# Altium schematic sheet MCU.SchDoc
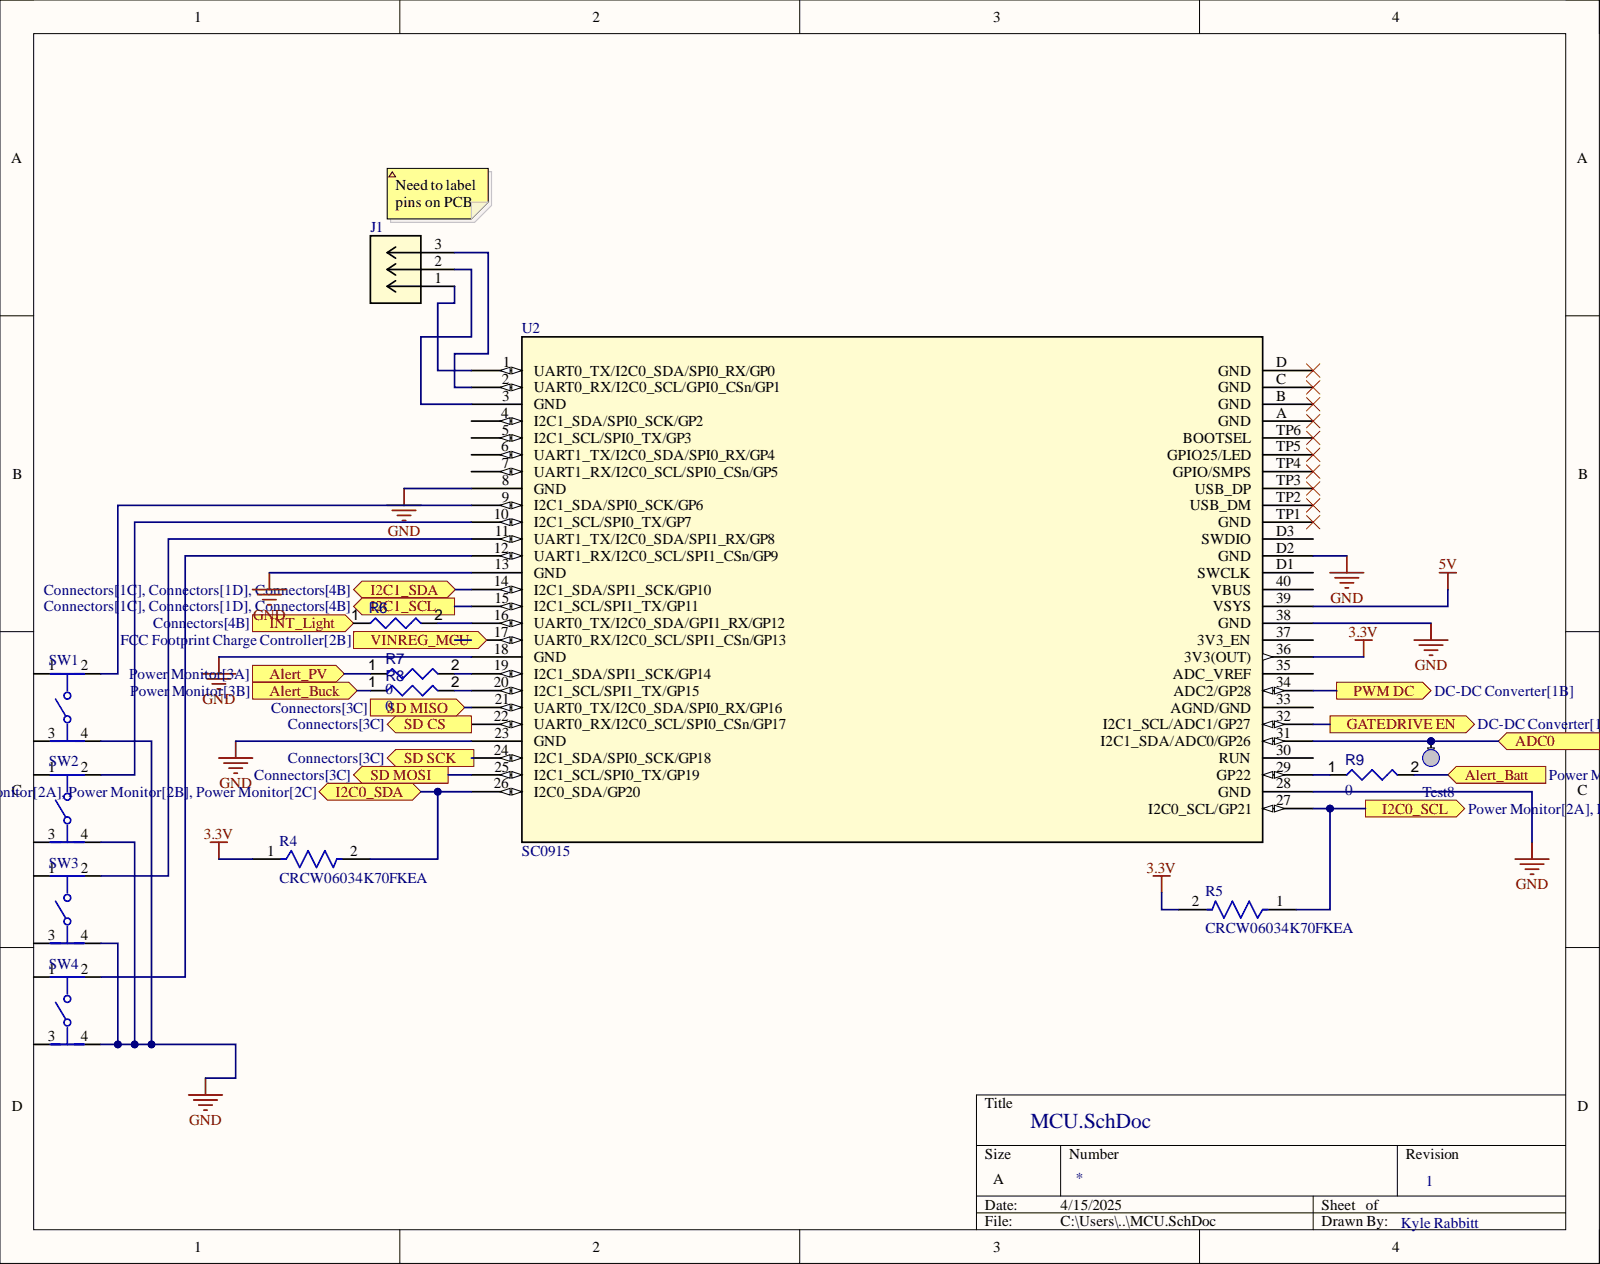
[diagram p0: MCU.SchDoc]

## Parts
J1  (no value)  [CONN_BM03B-SRSS-TBLFSN_JST]
R4  CRCW06034K70FKEA  [FP-CRCW0603-e3-IPC_A]
R5  CRCW06034K70FKEA  [FP-CRCW0603-e3-IPC_A]
R6  value 0  [RESC0603(1608)_L]  (hidden comment: 0R3J)
R7  0R3J  [RESC0603(1608)_L]  (value not drawn)
R8  value 0  [RESC0603(1608)_L]  (hidden comment: 0R3J)
R9  value 0  [RESC0603(1608)_L]  (hidden comment: 0R3J)
SW1  (no value)  [FP-PTS645SM43SMTR92LFS-MFG]
SW2  (no value)  [FP-PTS645SM43SMTR92LFS-MFG]
SW3  (no value)  [FP-PTS645SM43SMTR92LFS-MFG]
SW4  (no value)  [FP-PTS645SM43SMTR92LFS-MFG]
Test8  TestPoint  [Test_IN]  (value not drawn)  (no BOM)
U2  SC0915  [SC0915_RPI]

## Ports
ADC0, Alert_Batt, Alert_Buck, Alert_PV, GATEDRIVE EN, I2C0_SCL, I2C0_SDA, I2C1_SCL, I2C1_SDA, INT_Light, PWM DC, SD CS, SD MISO, SD MOSI, SD SCK, VINREG_MCU

## Nets
3.3V: R4.1 R5.2 U2.36(3V3(OUT))
5V: U2.39(VSYS)
GND: SW1.4 SW2.4 SW3.4 SW4.4 U2.8(GND) U2.13(GND) U2.18(GND) U2.23(GND) U2.28(GND) U2.38(GND) U2.D2(GND)
ADC0: Test8.IN U2.31(I2C1_SDA/ADC0/GP26) ; port ADC0
Alert_Batt: R9.2 ; port Alert_Batt
Alert_Buck: R8.1 ; port Alert_Buck
Alert_PV: R7.1 ; port Alert_PV
GATEDRIVE EN: U2.32(I2C1_SCL/ADC1/GP27) ; port GATEDRIVE EN
I2C0_SCL: R5.1 U2.27(I2C0_SCL/GP21) ; port I2C0_SCL
I2C0_SDA: R4.2 U2.26(I2C0_SDA/GP20) ; port I2C0_SDA
I2C1_SCL: U2.15(I2C1_SCL/SPI1_TX/GP11) ; port I2C1_SCL
I2C1_SDA: U2.14(I2C1_SDA/SPI1_SCK/GP10) ; port I2C1_SDA
INT_Light: R6.1 ; port INT_Light
PWM DC: U2.34(ADC2/GP28) ; port PWM DC
SD CS: U2.22(UART0_RX/I2C0_SCL/SPI0_CSn/GP17) ; port SD CS
SD MISO: U2.21(UART0_TX/I2C0_SDA/SPI0_RX/GP16) ; port SD MISO
SD MOSI: U2.25(I2C1_SCL/SPI0_TX/GP19) ; port SD MOSI
SD SCK: U2.24(I2C1_SDA/SPI0_SCK/GP18) ; port SD SCK
NetJ1_1: J1.1 U2.1(UART0_TX/I2C0_SDA/SPI0_RX/GP0)
NetJ1_2: J1.2 U2.3(GND)
NetJ1_3: J1.3 U2.2(UART0_RX/I2C0_SCL/GPI0_CSn/GP1)
NetR6_2: R6.2 U2.16(UART0_TX/I2C0_SDA/GPI1_RX/GP12)
NetR7_2: R7.2 U2.19(I2C1_SDA/SPI1_SCK/GP14)
NetR8_2: R8.2 U2.20(I2C1_SCL/SPI1_TX/GP15)
NetR9_1: R9.1 U2.29(GP22)
NetSW1_2: SW1.2 U2.9(I2C1_SDA/SPI0_SCK/GP6)
NetSW2_2: SW2.2 U2.10(I2C1_SCL/SPI0_TX/GP7)
NetSW3_2: SW3.2 U2.11(UART1_TX/I2C0_SDA/SPI1_RX/GP8)
NetSW4_2: SW4.2 U2.12(UART1_RX/I2C0_SCL/SPI1_CSn/GP9)
N00001: ; port VINREG_MCU

Not connected: SW1 1 3; SW2 1 3; SW3 1 3; SW4 1 3; U2 4(I2C1_SDA/SPI0_SCK/GP2) 5(I2C1_SCL/SPI0_TX/GP3) 6(UART1_TX/I2C0_SDA/SPI0_RX/GP4) 7(UART1_RX/I2C0_SCL/SPI0_CSn/GP5) 17(UART0_RX/I2C0_SCL/SPI1_CSn/GP13) 30(RUN) 33(AGND/GND) 35(ADC_VREF) 37(3V3_EN) 40(VBUS) A(GND) B(GND) C(GND) D(GND) D1(SWCLK) D3(SWDIO) TP1(GND) TP2(USB_DM) TP3(USB_DP) TP4(GPIO/SMPS) TP5(GPIO25/LED) TP6(BOOTSEL)

## Library data of the parts
J1 = BM03B-SRSS-TBLFSN: No Description Available; Mfr_Name: JST; Component Kind: Standard; Library Name: BM03B-SRSS-TBLFSN.SchLib; RefDes: RefDes; Type: Type
R4 R5 = CMP-1666-00019-3: RES Thick Film, 4.7kΩ, 1%, 0.1W, 100ppm/°C, 0603; Power Rating: 100mW; Resistance: 4.7kR; Voltage Rating: 75V; Case Code (Imperial): 0603; Case Code (Metric): 1608; Case/Package: 0603; Component Kind: Standard; Composition: Thick Film; Contact Plating: Tin; Depth: 0.85mm; Height: 0.45mm; Lead Free: Lead Free; Length: 1.55mm; Library Name: Altium Content Vault; Max Operating Temperature: 155°C; Military Standard: Not; Min Operating Temperature: -55°C; Mount: Surface Mount; Package Quantity: 5000; Packaging: Tape and Reel; Temperature Coefficient: 100ppm/°C; Termination: SMD/SMT; Tolerance: 1%; Voltage Rating (DC): 75V; Weight: 7.1E-05oz; Width: 0.85mm
R6 R8 R9 = CMP-009-00063-2: Jumper 0603 (1608 Metric); Case/Package: 0603; Component Kind: Standard; Mounting Technology: SMT; Pins: 2; Library Name: WPI High Power Rocketry Club
R7 = CMP-009-00063-2: Jumper 0603 (1608 Metric); Value: 0; Case/Package: 0603; Component Kind: Standard; Mounting Technology: SMT; Pins: 2; Library Name: WPI High Power Rocketry Club
SW1-SW4 = CMP-2000-05160-2: SWITCH TACTILE SPST-NO 0.05A 12V; Actuator Length: 0.85mm; Actuator Material: Nylon; Actuator Type: Standard; Contact Resistance: 100mR; Current Rating: 50mA; Illumination Type: Non-Illuminated; Mechanical Life: 100000Cycles; Operating Force: 160gf; Switch Function: Off-Mom; Throw Configuration: SPST; Case/Package: SMD/SMT; Color: Black; Component Kind: Standard; Contact Material: Silver; Contact Plating: Silver; Depth: 9mm; Lead Free: Lead Free; Length: 6mm; Library Name: Altium Content Vault; Max Current Rating: 50mA; Max Operating Temperature: 60°C; Max Voltage Rating (DC): 12V; Min Operating Temperature: -20°C; Mount: Surface Mount; Orientation: Straight; Package Quantity: 1; Packaging: Tape and Reel; REACH SVHC: No SVHC; Termination: Gull Wing; Voltage Rating (DC): 12V
U2 = SC0915: No Description Available; Mfr_Name: Raspberry Pi; Component Kind: Standard; Library Name: SC0915.SchLib; RefDes: RefDes; Type: Type
Test8 = TestPoint: Library Name: Test_POINTS.IntLib
